# Altium schematic sheet esp32_d1.SchDoc(esp32_d1)
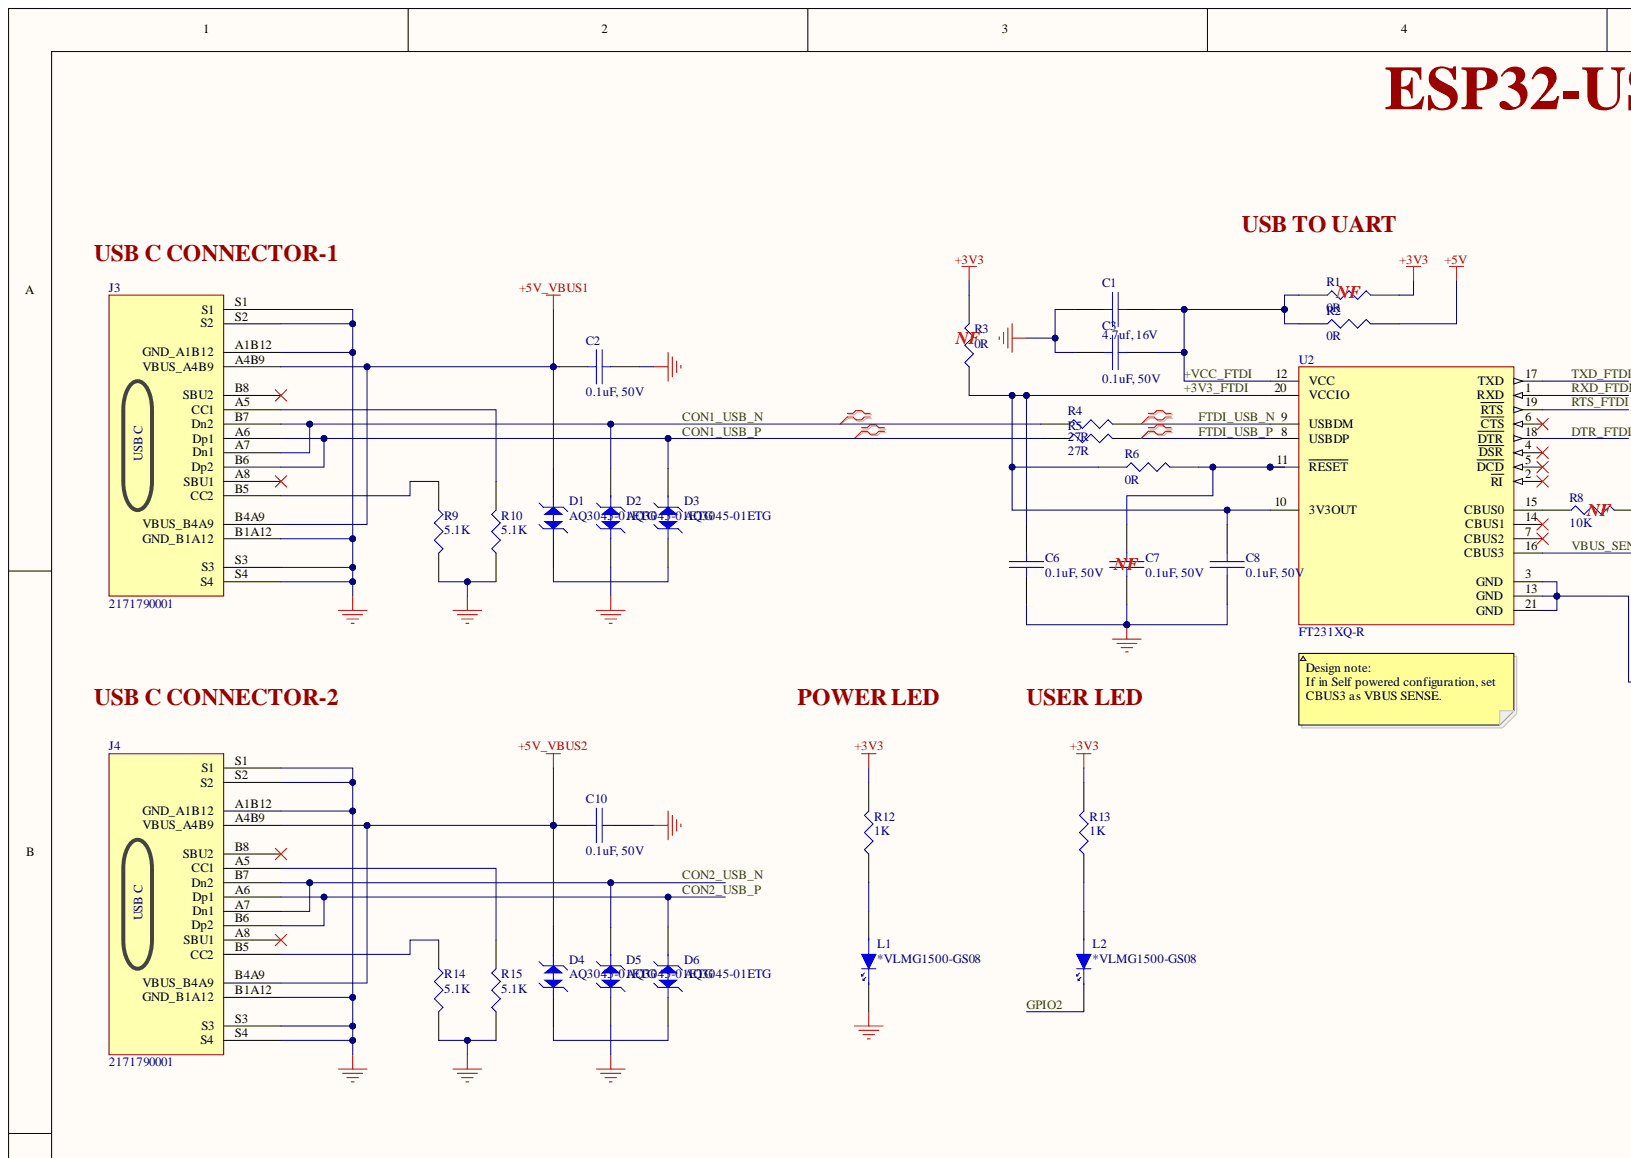
[diagram p0: esp32_d1.SchDoc, top left]
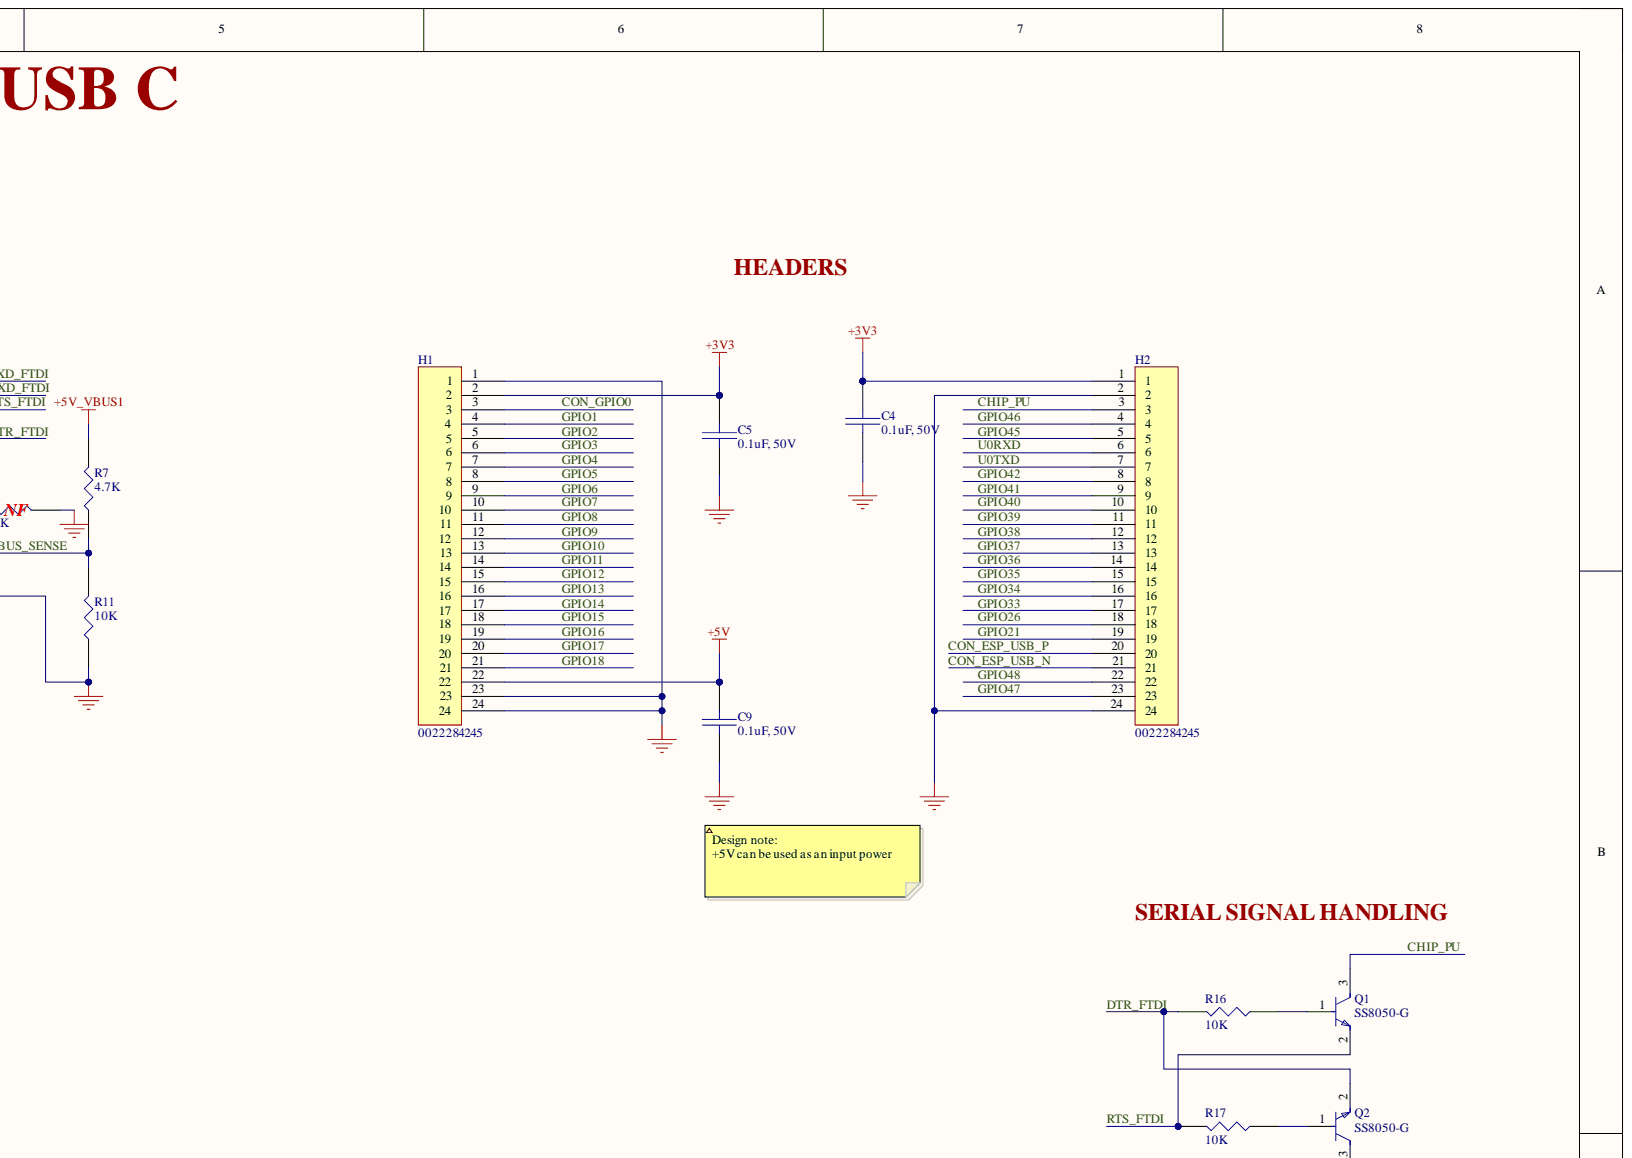
[diagram p1: esp32_d1.SchDoc, top right]
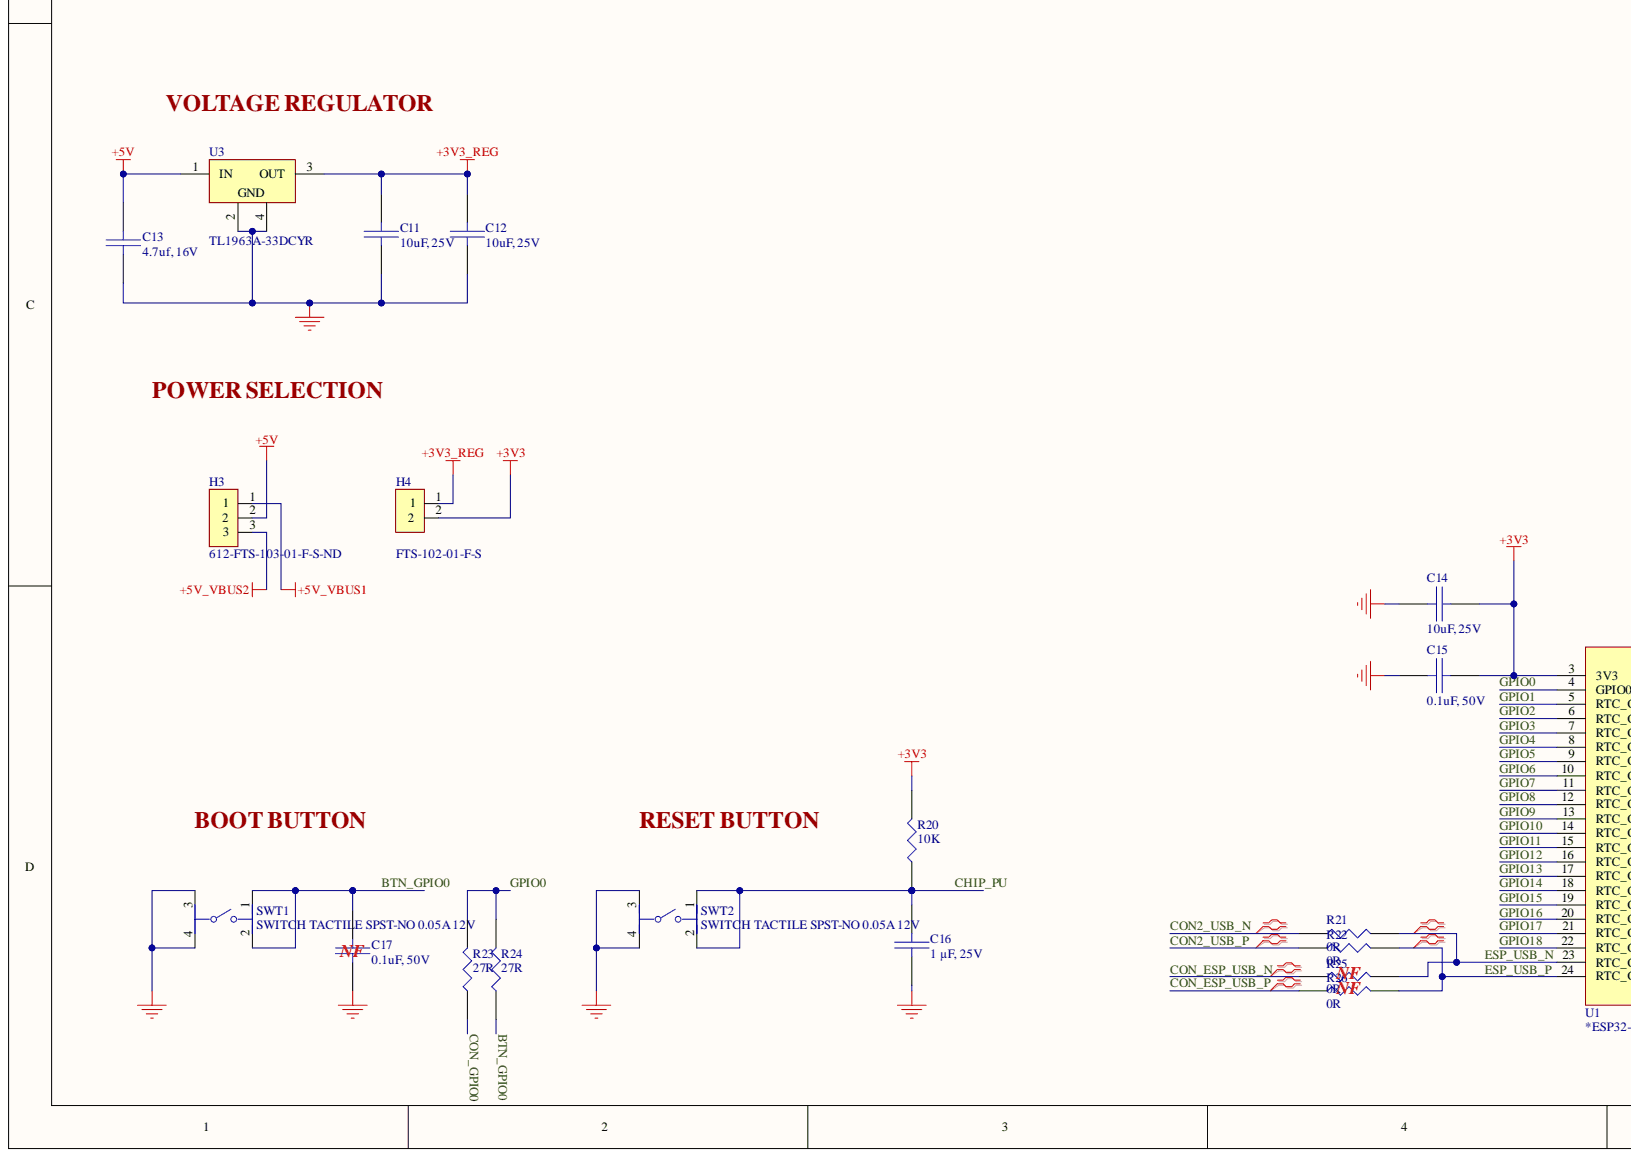
[diagram p2: esp32_d1.SchDoc, bottom left]
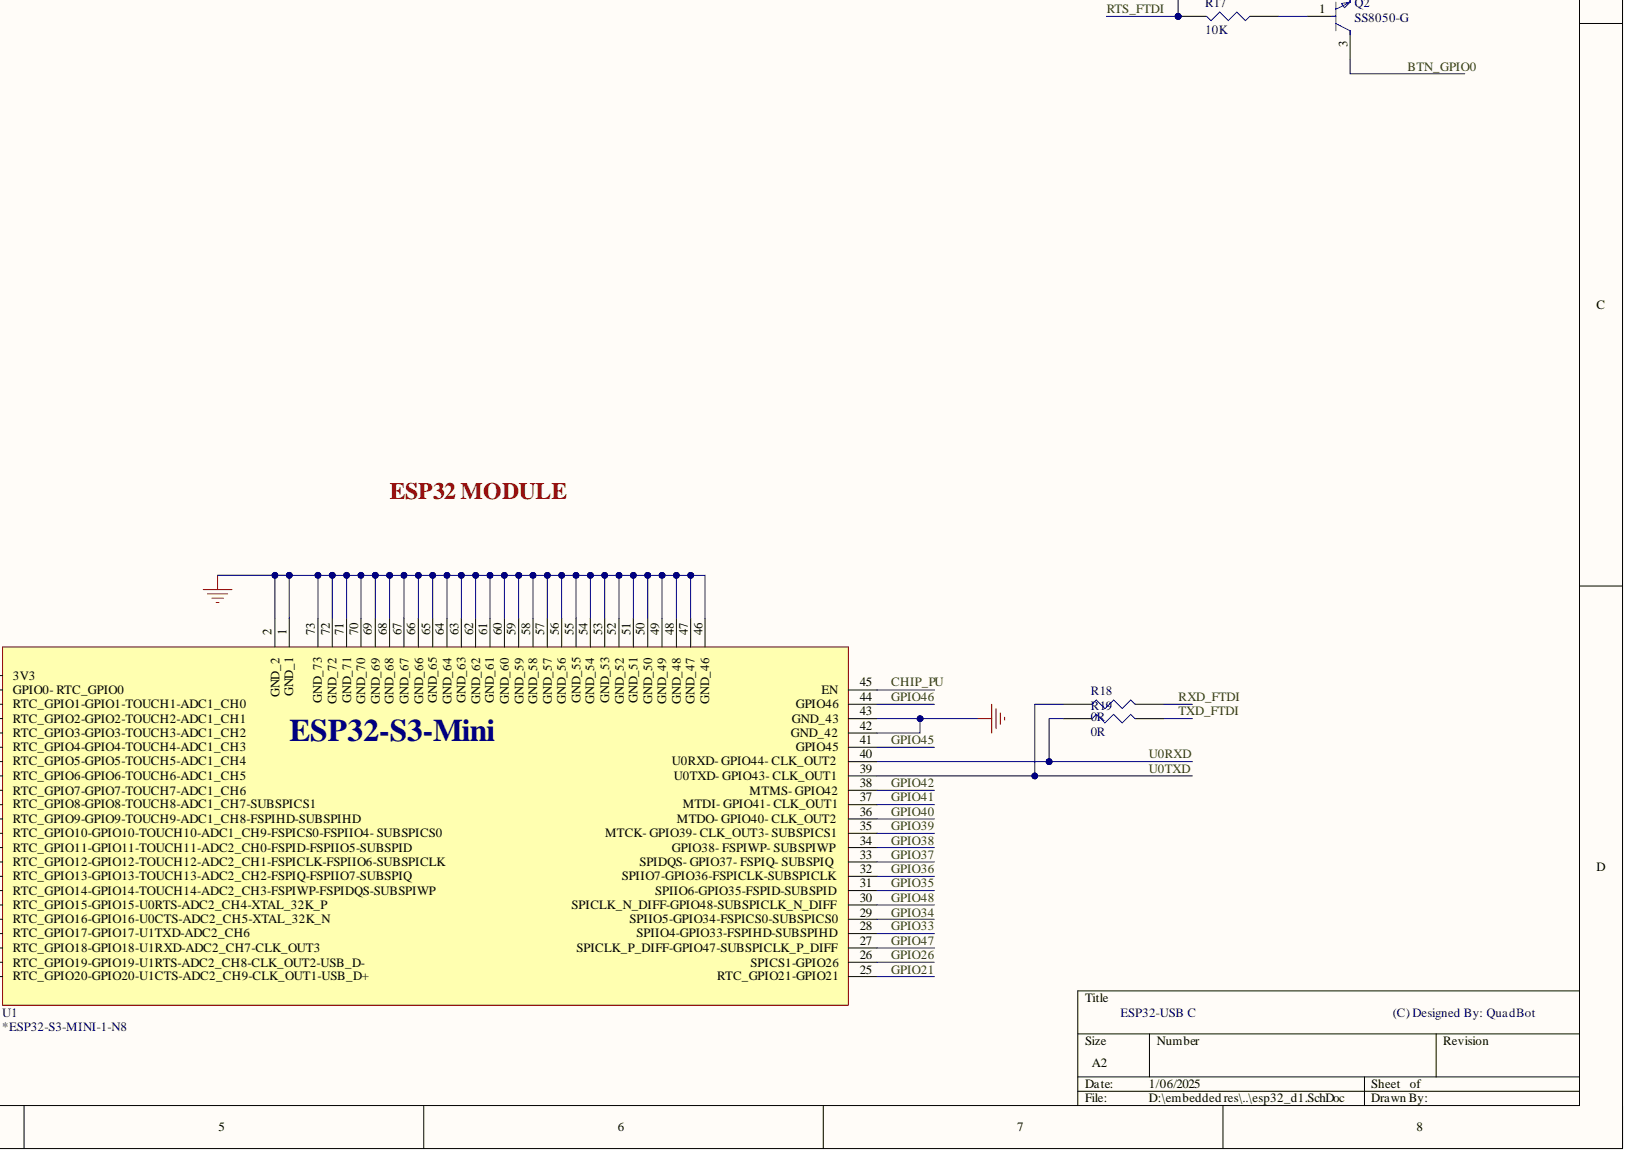
[diagram p3: esp32_d1.SchDoc, bottom right]

## Parts
C1  4.7uf, 16V  Rated DC Voltage (URdc): 16V  [CAPC1005X55N]
C2  0.1uF, 50V  [CAPC1005X55N]
C3  0.1uF, 50V  [CAPC1005X55N]
C4  0.1uF, 50V  [CAPC1005X55N]
C5  0.1uF, 50V  [CAPC1005X55N]
C6  0.1uF, 50V  [CAPC1005X55N]
C7  0.1uF, 50V  [CAPC1005X55N]
C8  0.1uF, 50V  [CAPC1005X55N]
C9  0.1uF, 50V  [CAPC1005X55N]
C10  0.1uF, 50V  [CAPC1005X55N]
C11  10uF, 25V  [CAPC1005X55N]
C12  10uF, 25V  [CAPC1005X55N]
C13  4.7uf, 16V  Rated DC Voltage (URdc): 16V  [CAPC1005X55N]
C14  10uF, 25V  [CAPC1005X55N]
C15  0.1uF, 50V  [CAPC1005X55N]
C16  1 µF, 25V  Rated DC Voltage (URdc): 25V  [CAPC1005X55N]
C17  0.1uF, 50V  [CAPC1005X55N]
D1  AQ3045-01ETG  [SOD882]
D2  AQ3045-01ETG  [SOD882]
D3  AQ3045-01ETG  [SOD882]
D4  AQ3045-01ETG  [SOD882]
D5  AQ3045-01ETG  [SOD882]
D6  AQ3045-01ETG  [SOD882]
H1  0022284245  [HDR_1X24_2.54MM]
H2  0022284245  [HDR_1X24_2.54MM]
H3  612-FTS-103-01-F-S-ND  [HDR_1X3_2.54MM]
H4  FTS-102-01-F-S  [HDR_1X2_2.54MM]
J3  2171790001  [FP-2171790001-MFG]
J4  2171790001  [FP-2171790001-MFG]
L1  *VLMG1500-GS08  [LEDC1005X35N]
L2  *VLMG1500-GS08  [LEDC1005X35N]
Q1  SS8050-G  [FP-SS8050-G-IPC_B]
Q2  SS8050-G  [FP-SS8050-G-IPC_B]
R1  0R  [RESC1005X40N]
R2  0R  [RESC1005X40N]
R3  0R  [RESC1005X40N]
R4  27R  [RESC1005X40N]
R5  27R  [RESC1005X40N]
R6  0R  [RESC1005X40N]
R7  4.7K  [RESC1005X40N]
R8  10K  [RESC1005X40N]
R9  5.1K  [RESC1005X40N]
R10  5.1K  [RESC1005X40N]
R11  10K  [RESC1005X40N]
R12  1K  [RESC1005X40N]
R13  1K  [RESC1005X40N]
R14  5.1K  [RESC1005X40N]
R15  5.1K  [RESC1005X40N]
R16  10K  [RESC1005X40N]
R17  10K  [RESC1005X40N]
R18  0R  [RESC1005X40N]
R19  0R  [RESC1005X40N]
R20  10K  [RESC1005X40N]
R21  0R  [RESC1005X40N]
R22  0R  [RESC1005X40N]
R23  27R  Working Voltage: 50V  [RESC1005X40N]
R24  27R  [RESC1005X40N]
R25  0R  [RESC1005X40N]
R26  0R  [RESC1005X40N]
SWT1  SWITCH TACTILE SPST-NO 0.05A 12V  [FP-PTS645SH50SMTR92LFS-MFG]
SWT2  SWITCH TACTILE SPST-NO 0.05A 12V  [FP-PTS645SH50SMTR92LFS-MFG]
U1  *ESP32-S3-MINI-1-N8  [XCVR_ESP32-S3-MINI-1-N8]
U2  FT231XQ-R  [FP-QFN-20-IPC_B]
U3  TL1963A-33DCYR  [DCY0004A_N]

## Nets
+3V3: C4.1 C5.1 C14.2 C15.2 H1.2 H2.1 H4.2 R1.2 R3.2 R12.2 R13.2 R20.2 U1.3(3V3)
+3V3_REG: C11.2 C12.2 H4.1 U3.3(OUT)
+5V: C9.1 C13.1 H1.22 H3.2 R2.2 U3.1(IN)
+5V_VBUS1: C2.2 D1.1 H3.1 J3.A4B9(VBUS_A4B9) J3.B4A9(VBUS_B4A9) R7.2
+5V_VBUS2: C10.2 D4.1 H3.3 J4.A4B9(VBUS_A4B9) J4.B4A9(VBUS_B4A9)
GND: C1.2 C2.1 C3.2 C4.2 C5.2 C6.2 C7.2 C8.2 C9.2 C10.1 C11.1 C12.1 C13.2 C14.1 C15.1 C16.2 C17.1 D2.2 D5.2 H1.1 H1.23 H1.24 H2.2 H2.24 J3.A1B12(GND_A1B12) J3.B1A12(GND_B1A12) J3.S1 J3.S2 J3.S3 J3.S4 J4.A1B12(GND_A1B12) J4.B1A12(GND_B1A12) J4.S1 J4.S2 J4.S3 J4.S4 L1.1 R8.2 R9.2 R10.1 R11.1 R14.2 R15.1 SWT1.3 SWT1.4 SWT2.3 SWT2.4 U1.1(GND_1) U1.2(GND_2) U1.42(GND_42) U1.43(GND_43) U1.46(GND_46) U1.47(GND_47) U1.48(GND_48) U1.49(GND_49) U1.50(GND_50) U1.51(GND_51) U1.52(GND_52) U1.53(GND_53) U1.54(GND_54) U1.55(GND_55) U1.56(GND_56) U1.57(GND_57) U1.58(GND_58) U1.59(GND_59) U1.60(GND_60) U1.61(GND_61) U1.62(GND_62) U1.63(GND_63) U1.64(GND_64) U1.65(GND_65) U1.66(GND_66) U1.67(GND_67) U1.68(GND_68) U1.69(GND_69) U1.70(GND_70) U1.71(GND_71) U1.72(GND_72) U1.73(GND_73) U2.3(GND) U2.13(GND) U2.21(GND) U3.2 U3.4
+3V3_FTDI: C6.1 C8.1 R3.1 R6.1 U2.10(3V3OUT) U2.20(VCCIO)
+VCC_FTDI: C1.1 C3.1 R1.1 R2.1 U2.12(VCC)
BTN_GPIO0: C17.2 Q2.3 R24.1 SWT1.1 SWT1.2
CHIP_PU: C16.1 H2.3 Q1.3 R20.1 SWT2.1 SWT2.2 U1.45(EN)
CON1_USB_N: D2.1 J3.A7(Dn1) J3.B7(Dn2) R4.1
CON1_USB_P: D3.1 J3.A6(Dp1) J3.B6(Dp2) R5.1
CON2_USB_N: D5.1 J4.A7(Dn1) J4.B7(Dn2) R21.1
CON2_USB_P: D6.1 J4.A6(Dp1) J4.B6(Dp2) R22.1
CON_ESP_USB_N: H2.21 R25.1
CON_ESP_USB_P: H2.20 R26.1
CON_GPIO0: H1.3 R23.1
DTR_FTDI: Q2.2 R16.2 U2.18(DTR)
ESP_USB_N: R21.2 R25.2 U1.23(RTC_GPIO19-GPIO19-U1RTS-ADC2_CH8-CLK_OUT2-USB_D-)
ESP_USB_P: R22.2 R26.2 U1.24(RTC_GPIO20-GPIO20-U1CTS-ADC2_CH9-CLK_OUT1-USB_D+)
FTDI_USB_N: R4.2 U2.9(USBDM)
FTDI_USB_P: R5.2 U2.8(USBDP)
GPIO0: R23.2 R24.2 U1.4(GPIO0-_RTC_GPIO0)
GPIO1: H1.4 U1.5(RTC_GPIO1-GPIO1-TOUCH1-ADC1_CH0)
GPIO2: H1.5 L2.1 U1.6(RTC_GPIO2-GPIO2-TOUCH2-ADC1_CH1)
GPIO3: H1.6 U1.7(RTC_GPIO3-GPIO3-TOUCH3-ADC1_CH2)
GPIO4: H1.7 U1.8(RTC_GPIO4-GPIO4-TOUCH4-ADC1_CH3)
GPIO5: H1.8 U1.9(RTC_GPIO5-GPIO5-TOUCH5-ADC1_CH4)
GPIO6: H1.9 U1.10(RTC_GPIO6-GPIO6-TOUCH6-ADC1_CH5)
GPIO7: H1.10 U1.11(RTC_GPIO7-GPIO7-TOUCH7-ADC1_CH6)
GPIO8: H1.11 U1.12(RTC_GPIO8-GPIO8-TOUCH8-ADC1_CH7-SUBSPICS1)
GPIO9: H1.12 U1.13(RTC_GPIO9-GPIO9-TOUCH9-ADC1_CH8-FSPIHD-SUBSPIHD)
GPIO10: H1.13 U1.14(RTC_GPIO10-GPIO10-TOUCH10-ADC1_CH9-FSPICS0-FSPIIO4-_SUBSPICS0)
GPIO11: H1.14 U1.15(RTC_GPIO11-GPIO11-TOUCH11-ADC2_CH0-FSPID-FSPIIO5-SUBSPID)
GPIO12: H1.15 U1.16(RTC_GPIO12-GPIO12-TOUCH12-ADC2_CH1-FSPICLK-FSPIIO6-SUBSPICLK)
GPIO13: H1.16 U1.17(RTC_GPIO13-GPIO13-TOUCH13-ADC2_CH2-FSPIQ-FSPIIO7-SUBSPIQ)
GPIO14: H1.17 U1.18(RTC_GPIO14-GPIO14-TOUCH14-ADC2_CH3-FSPIWP-FSPIDQS-SUBSPIWP)
GPIO15: H1.18 U1.19(RTC_GPIO15-GPIO15-U0RTS-ADC2_CH4-XTAL_32K_P)
GPIO16: H1.19 U1.20(RTC_GPIO16-GPIO16-U0CTS-ADC2_CH5-XTAL_32K_N)
GPIO17: H1.20 U1.21(RTC_GPIO17-GPIO17-U1TXD-ADC2_CH6)
GPIO18: H1.21 U1.22(RTC_GPIO18-GPIO18-U1RXD-ADC2_CH7-CLK_OUT3)
GPIO21: H2.19 U1.25(RTC_GPIO21-GPIO21)
GPIO26: H2.18 U1.26(SPICS1-GPIO26)
GPIO33: H2.17 U1.28(SPIIO4-GPIO33-FSPIHD-SUBSPIHD)
GPIO34: H2.16 U1.29(SPIIO5-GPIO34-FSPICS0-SUBSPICS0)
GPIO35: H2.15 U1.31(SPIIO6-GPIO35-FSPID-SUBSPID)
GPIO36: H2.14 U1.32(SPIIO7-GPIO36-FSPICLK-SUBSPICLK)
GPIO37: H2.13 U1.33(SPIDQS-_GPIO37-_FSPIQ-_SUBSPIQ)
GPIO38: H2.12 U1.34(GPIO38-_FSPIWP-_SUBSPIWP)
GPIO39: H2.11 U1.35(MTCK-_GPIO39-_CLK_OUT3-_SUBSPICS1)
GPIO40: H2.10 U1.36(MTDO-_GPIO40-_CLK_OUT2)
GPIO41: H2.9 U1.37(MTDI-_GPIO41-_CLK_OUT1)
GPIO42: H2.8 U1.38(MTMS-_GPIO42)
GPIO45: H2.5 U1.41(GPIO45)
GPIO46: H2.4 U1.44(GPIO46)
GPIO47: H2.23 U1.27(SPICLK_P_DIFF-GPIO47-SUBSPICLK_P_DIFF)
GPIO48: H2.22 U1.30(SPICLK_N_DIFF-GPIO48-SUBSPICLK_N_DIFF)
RTS_FTDI: Q1.2 R17.2 U2.19(RTS)
RXD_FTDI: R18.2 U2.1(RXD)
TXD_FTDI: R19.2 U2.17(TXD)
U0RXD: H2.6 R19.1 U1.40(U0RXD-_GPIO44-_CLK_OUT2)
U0TXD: H2.7 R18.1 U1.39(U0TXD-_GPIO43-_CLK_OUT1)
VBUS_SENSE: R7.1 R11.2 U2.16(CBUS3)
NetC7_1: C7.1 R6.2 U2.11(RESET)
NetD1_2: D1.2 D3.2
NetD4_2: D4.2 D6.2
NetJ3_A5: J3.A5(CC1) R10.2
NetJ3_B5: J3.B5(CC2) R9.1
NetJ4_A5: J4.A5(CC1) R15.2
NetJ4_B5: J4.B5(CC2) R14.1
NetL1_2: L1.2 R12.1
NetL2_2: L2.2 R13.1
NetQ1_1: Q1.1 R16.1
NetQ2_1: Q2.1 R17.1
NetR8_1: R8.1 U2.15(CBUS0)

Not connected: J3 A8(SBU1) B8(SBU2); J4 A8(SBU1) B8(SBU2); U2 2(RI) 4(DSR) 5(DCD) 6(CTS) 7(CBUS2) 14(CBUS1)
